# Altium schematic sheet LightBoard_v1.0.SchDoc
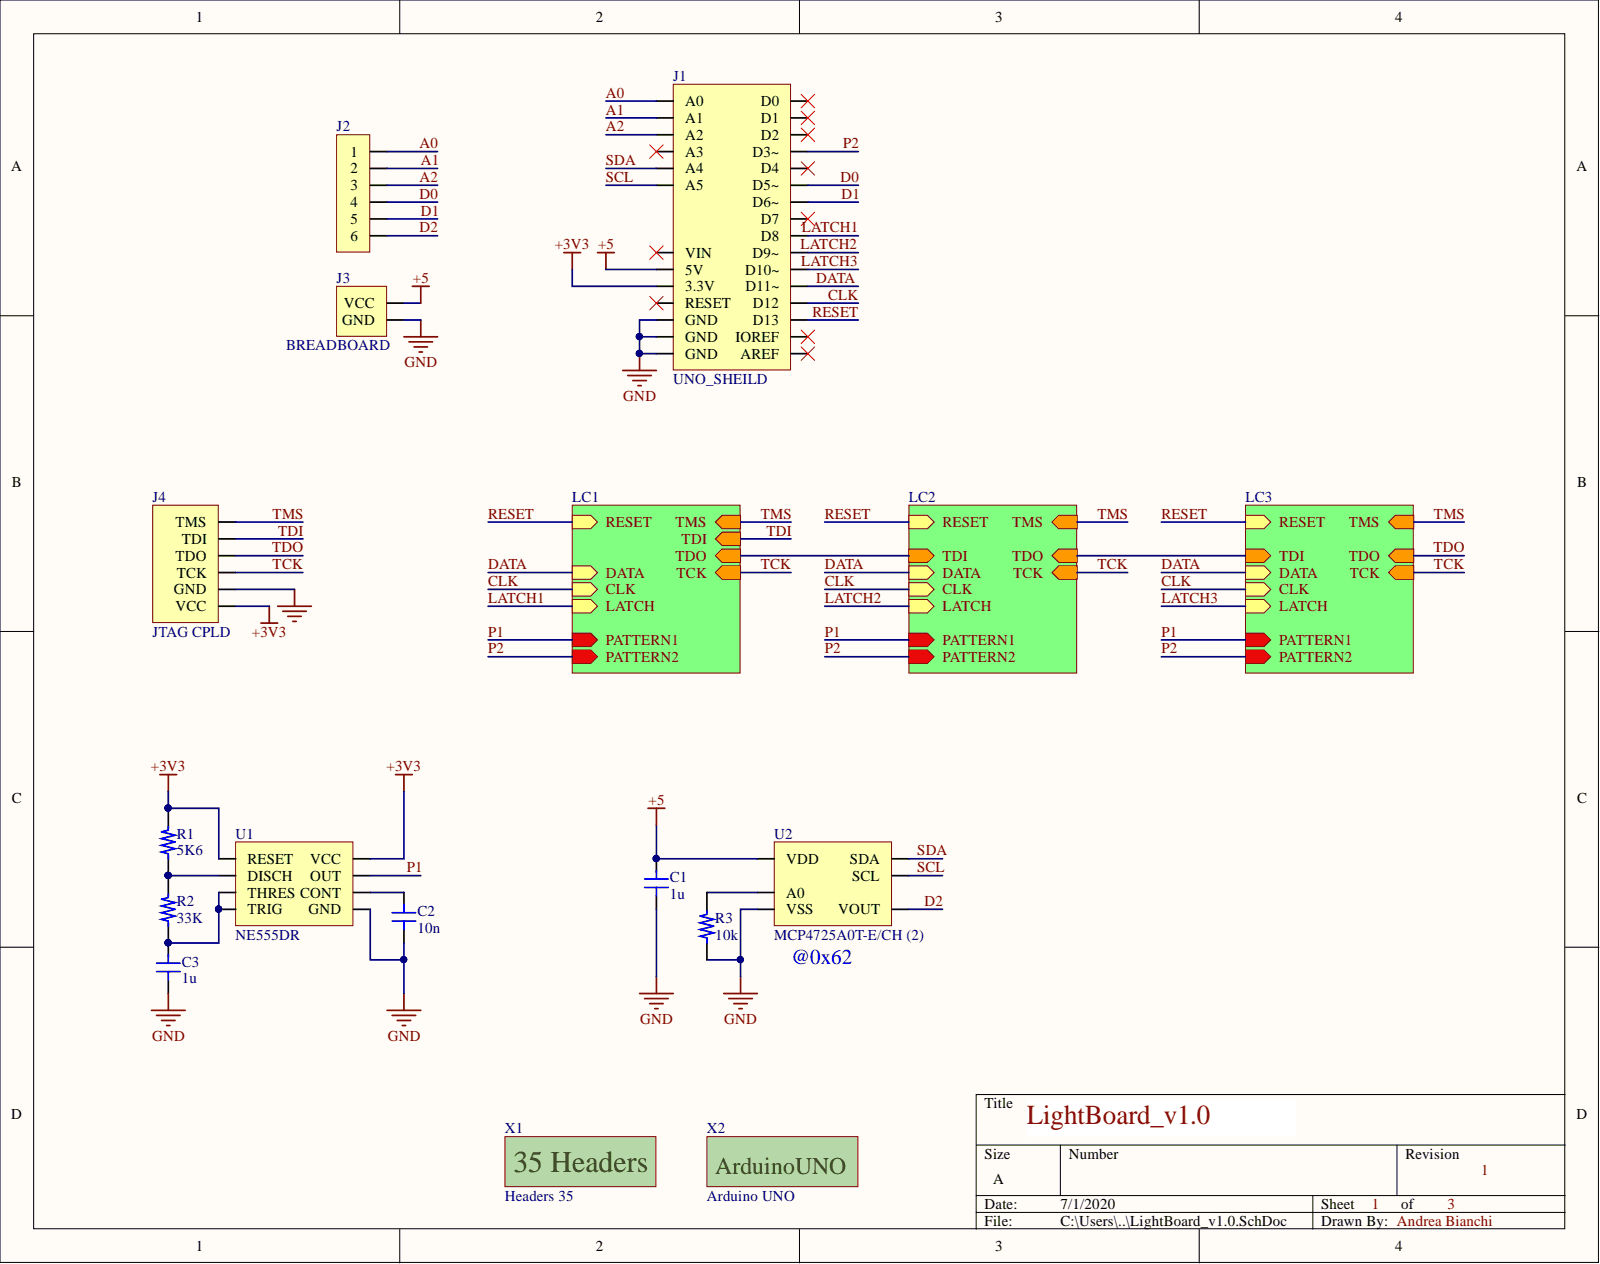
[diagram p0: LightBoard_v1.0.SchDoc]

## Parts
C1  1u
C2  10n
C3  1u
J1  UNO_SHEILD  [ARDUINO_UNO_SHIELD]
J2  HDR_6_PINS  (value not drawn)
J3  BREADBOARD  (mechanical)
J4  JTAG CPLD
R1  5K6
R2  33K
R3  10k
U1  NE555DR
U2  MCP4725A0T-E/CH (2)  Manufacturer Part Number: MCP4725A0T-E/CH  [SOT23-6]
X1  Headers 35  (mechanical)
X2  Arduino UNO  (mechanical)

## Nets
+3V3: J1.27(3.3V) J4.6(VCC) R1.2 U1.4(RESET) U1.8(VCC)
+5: C1.2 J1.26(5V) J3.1 U2.3(VDD)
GND: C1.1 C2.1 C3.1 J1.15(GND) J1.24(GND) J1.25(GND) J3.2 J4.5(GND) R3.1 U1.1(GND) U2.2(VSS)
A0: J1.22(A0) J2.1
A1: J1.21(A1) J2.2
A2: J1.20(A2) J2.3
CLK: J1.13(D12)
D0: J1.6(D5~) J2.4
D1: J1.7(D6~) J2.5
D2: J2.6 U2.1(VOUT)
DATA: J1.12(D11~)
LATCH1: J1.9(D8)
LATCH2: J1.10(D9~)
LATCH3: J1.11(D10~)
P1: U1.3(OUT)
P2: J1.4(D3~)
RESET: J1.14(D13)
SCL: J1.17(A5) U2.5(SCL)
SDA: J1.18(A4) U2.4(SDA)
TCK: J4.4(TCK)
TDI: J4.2(TDI)
TDO: J4.3(TDO)
TMS: J4.1(TMS)
NetC2_2: C2.2 U1.5(CONT)
NetC3_2: C3.2 R2.1 U1.2(TRIG) U1.6(THRES)
NetJ1_1: J1.1(D0)
NetJ1_2: J1.2(D1)
NetJ1_3: J1.3(D2)
NetJ1_5: J1.5(D4)
NetJ1_8: J1.8(D7)
NetJ1_16: J1.16(AREF)
NetJ1_19: J1.19(A3)
NetJ1_23: J1.23(VIN)
NetJ1_28: J1.28(RESET)
NetJ1_29: J1.29(IOREF)
NetR1_1: R1.1 R2.2 U1.7(DISCH)
NetR3_2: R3.2 U2.6(A0)

## Library data of the parts
C1 C3 = C0805_1u: CERAMIC CAPACITOR, 1UF, 25V, Y5V, +80, -20%, 0805, FULL REEL; Product Range:CC Series; Capacitance:1 F; Capacitance Tolerance:+80%, -20%; Dielectric Characteristic:Y5V; Voltage Rating:25V; Ceramic Capacitor Case:0805 [2012 Metric] ;RoHS Compliant: Yes; Manufacturer: Yageo; Manufacturer Part Number: CC0805ZRY5V8BB105; Tolerance: 80%; Capacitance: 1uF; Case/Package: 0805; Case Code (Imperial): 0805; Case Code (Metric): 2012; Component Kind: Standard; ComponentLink1Description: https://datasheet.ciiva.com/26863/getdatasheetpartid-419810-26863011.pdf?src-supplier=Verical; ComponentLink1URL: https://datasheet.ciiva.com/26863/getdatasheetpartid-419810-26863011.pdf?src-supplier=Verical; ComponentLink2Description: https://datasheet.ciiva.com/144/mlccorderingcode-144703.pdf?src-supplier=Digi-Key; ComponentLink2URL: https://datasheet.ciiva.com/144/mlccorderingcode-144703.pdf?src-supplier=Digi-Key; ComponentLink3Description: https://datasheet.ciiva.com/144/mlccorderingcode-144704.pdf?src-supplier=Digi-Key; ComponentLink3URL: https://datasheet.ciiva.com/144/mlccorderingcode-144704.pdf?src-supplier=Digi-Key; ComponentLink4Description: https://datasheet.ciiva.com/144/mlccorderingcode-144705.pdf?src-supplier=Digi-Key; ComponentLink4URL: https://datasheet.ciiva.com/144/mlccorderingcode-144705.pdf?src-supplier=Digi-Key; ComponentLink5Description: https://datasheet.ciiva.com/13208/upy-gp-np0-16v-to-50v-8-13208251.pdf?src-supplier=Mouser; ComponentLink5URL: https://datasheet.ciiva.com/13208/upy-gp-np0-16v-to-50v-8-13208251.pdf?src-supplier=Mouser; ComponentLink6Description: https://datasheet.ciiva.com/28450/1132289-28450860.pdf?src-supplier=Element14; ComponentLink6URL: https://datasheet.ciiva.com/28450/1132289-28450860.pdf?src-supplier=Element14; Composition: Ceramic; Depth: 1.25mm; Dielectric: Y5V; Halogen Free: Halogen Free; Height: 0.85mm; Lead Free: Lead Free; Length: 2mm; Library Name: LightBoard_v1.0.SchLib; Max Operating Temperature: 85°C; Min Operating Temperature: -30°C; Mount: Surface Mount; Package Quantity: 1; Packaging: Tape and Reel; Pricing 1: 1 = 0.16000, 10 = 0.11400, 100 = 0.05340, 500 = 0.03748, 1000 = 0.03102 (USD); Radiation Hardening: No; REACH SVHC: No SVHC; RoHS: Yes; Stock 1: 145970; Supplier 1: Digi-Key; Supplier Part Number 1: 311-1358-1-ND; Termination: SMD/SMT; Thickness: 0.033inch; Voltage Rating: 25V; Voltage Rating (DC): 25V; Weight: 0.000194oz; Width: 0.049inch
C2 = C0402_10n: Cap Ceramic 0.01uF 10V X7R 10% SMD 0402 125C Paper T/R; Manufacturer: Yageo; Manufacturer Part Number: CC0402KRX7R6BB103; Capacitance: 10nF; Case/Package: 0402; Case Code (Imperial): 0402; Case Code (Metric): 1005; Component Kind: Standard; ComponentLink1Description: https://datasheet.ciiva.com/26862/getdatasheetpartid-748492-26862629.pdf?src-supplier=Verical; ComponentLink1URL: https://datasheet.ciiva.com/26862/getdatasheetpartid-748492-26862629.pdf?src-supplier=Verical; Composition: Ceramic; Depth: 0.5mm; Dielectric: X7R; Halogen Free: Halogen Free; Lead Free: Lead Free; Length: 1mm; Library Name: LightBoard_v1.0.SchLib; Max Operating Temperature: 125°C; Min Operating Temperature: -55°C; Mount: Surface Mount; Packaging: Tape and Reel; Pricing 1: 1 = 0.10000, 10 = 0.02100, 100 = 0.00950, 500 = 0.00678, 1000 = 0.00532, 2500 = 0.00484, 5000 = 0.00445 (USD); Radiation Hardening: No; RoHS: Yes; Stock 1: 30463; Supplier 1: Digi-Key; Supplier Part Number 1: 311-1703-1-ND; Termination: SMD/SMT; Thickness: 0.022inch; Tolerance: 10%; Voltage Rating: 10V; Voltage Rating (DC): 10V; Weight: 2.3E-05oz; Width: 0.02inch
J1 = ARDUINO_UNO_SHIELD: Arduino UNO shield; Manufacturer Part Number: A000073; Core Architecture: AVR; Evaluation Kit: Yes; Number of Bits: 8; Component Kind: Standard; ComponentLink1Description: https://datasheet.ciiva.com/22632/1682193-22632354.pdf?src-supplier=Element14; ComponentLink1URL: https://datasheet.ciiva.com/22632/1682193-22632354.pdf?src-supplier=Element14; ComponentLink2Description: https://datasheet.ciiva.com/4710/unosmd-188790-4710628.pdf?src-supplier=Mouser; ComponentLink2URL: https://datasheet.ciiva.com/4710/unosmd-188790-4710628.pdf?src-supplier=Mouser; ComponentLink3Description: https://datasheet.ciiva.com/25630/0900766b811af13a-25630647.pdf?src-supplier=RSComponents; ComponentLink3URL: https://datasheet.ciiva.com/25630/0900766b811af13a-25630647.pdf?src-supplier=RSComponents; ComponentLink4Description: https://datasheet.ciiva.com/19466/2163840-19466249.pdf?src-supplier=Jameco; ComponentLink4URL: https://datasheet.ciiva.com/19466/2163840-19466249.pdf?src-supplier=Jameco; ComponentLink5Description: https://datasheet.ciiva.com/6204/a000073-web-6204795.pdf?src-supplier=Digi-Key; ComponentLink5URL: https://datasheet.ciiva.com/6204/a000073-web-6204795.pdf?src-supplier=Digi-Key; ComponentLink6Description: https://datasheet.ciiva.com/20168/73-4443-20168541.pdf?src-supplier=Rapid+Electronics; ComponentLink6URL: https://datasheet.ciiva.com/20168/73-4443-20168541.pdf?src-supplier=Rapid+Electronics; Height: 0.05inch; Length: 3inch; Library Name: LightBoard_v1.0.SchLib; Manufacturer: Arduino; Mount: Surface Mount; Package Quantity: 1; Packaging: Bulk; Pricing 1: 1 = 20.90000 (USD); REACH SVHC: Unknown; RoHS: Yes; Stock 1: 2726; Supplier 1: Digi-Key; Supplier Part Number 1: 1050-1041-ND; Weight: 0.02lb; Width: 2.5inch
J2 = H_ARDUPINS: Component Kind: Standard; Library Name: LightBoard_v1.0.SchLib
J3 = BREADBOARD: 26*2 ROWS; Library Name: LightBoard_v1.0.SchLib
J4 = H_JTAG: Component Kind: Standard; Library Name: LightBoard_v1.0.SchLib
R1 = R0402_5K6: RES SMD 5.6K OHM 5% 1/16W 0402; Manufacturer: Yageo; Manufacturer Part Number: RC0402JR-075K6L; Max Power Dissipation: 63mW; Resistance: 5.6kR; Temperature Coefficient: 100ppm/°C; Voltage Rating: 50V; Case/Package: 0402; Case Code (Imperial): 0402; Case Code (Metric): 1005; Component Kind: Standard; ComponentLink1Description: https://datasheet.ciiva.com/16746/pyu-rc0402-51-rohs-l-6-r-16746885.pdf?src-supplier=Future+Electronics; ComponentLink1URL: https://datasheet.ciiva.com/16746/pyu-rc0402-51-rohs-l-6-r-16746885.pdf?src-supplier=Future+Electronics; ComponentLink2Description: https://datasheet.ciiva.com/12577/pyu-rc0402-51-rohs-l-6-r-12577430.pdf?src-supplier=Mouser; ComponentLink2URL: https://datasheet.ciiva.com/12577/pyu-rc0402-51-rohs-l-6-r-12577430.pdf?src-supplier=Mouser; ComponentLink3Description: https://datasheet.ciiva.com/7850/1018594-7850232.pdf?src-supplier=Element14; ComponentLink3URL: https://datasheet.ciiva.com/7850/1018594-7850232.pdf?src-supplier=Element14; ComponentLink4Description: https://datasheet.ciiva.com/8892/pyu-rc0402-51-rohs-l-6-8892584.pdf?src-supplier=Avnet; ComponentLink4URL: https://datasheet.ciiva.com/8892/pyu-rc0402-51-rohs-l-6-8892584.pdf?src-supplier=Avnet; ComponentLink5Description: https://datasheet.ciiva.com/16862/pyu-rc0402-51-rohs-l-6-16862504.pdf?src-supplier=Avnet+Europe; ComponentLink5URL: https://datasheet.ciiva.com/16862/pyu-rc0402-51-rohs-l-6-16862504.pdf?src-supplier=Avnet+Europe; ComponentLink6Description: https://datasheet.ciiva.com/7850/1018594-7850233.pdf?src-supplier=Element14; ComponentLink6URL: https://datasheet.ciiva.com/7850/1018594-7850233.pdf?src-supplier=Element14; ComponentLink7Description: https://datasheet.ciiva.com/2518/pyu-r-int-thick-7-2518877.pdf?src-supplier=Digi-Key; ComponentLink7URL: https://datasheet.ciiva.com/2518/pyu-r-int-thick-7-2518877.pdf?src-supplier=Digi-Key; ComponentLink8Description: https://datasheet.ciiva.com/7983/1018594-7983597.pdf?src-supplier=Element14; ComponentLink8URL: https://datasheet.ciiva.com/7983/1018594-7983597.pdf?src-supplier=Element14; ComponentLink9Description: https://datasheet.ciiva.com/2518/pyu-r-int-thick-7-2518878.pdf?src-supplier=Digi-Key; ComponentLink9URL: https://datasheet.ciiva.com/2518/pyu-r-int-thick-7-2518878.pdf?src-supplier=Digi-Key; ComponentLink10Description: https://datasheet.ciiva.com/2518/pyu-r-int-thick-7-2518879.pdf?src-supplier=Digi-Key; ComponentLink10URL: https://datasheet.ciiva.com/2518/pyu-r-int-thick-7-2518879.pdf?src-supplier=Digi-Key; Composition: Thick Film; Contact Plating: Tin,Matte; Depth: 0.5mm; Features: Moisture Resistant; Height: 0.016inch; Lead Free: Lead Free; Length: 1mm; Library Name: LightBoard_v1.0.SchLib; Max Operating Temperature: 155°C; Military Standard: Not; Min Operating Temperature: -55°C; Number of Terminations: 2; Package Quantity: 1; Packaging: Tape and Reel; Power Rating: 63mW; Pricing 1: 1 = 0.10000, 10 = 0.01300, 100 = 0.00530, 1000 = 0.00236, 2500 = 0.00205, 5000 = 0.00169 (USD); REACH SVHC: Unknown; RoHS: Yes; Stock 1: 70470; Supplier 1: Digi-Key; Supplier Part Number 1: 311-5.6KJRCT-ND; Termination: SMD/SMT; Tolerance: 5%; Weight: 2.3E-05oz; Width: 0.5mm
R2 = R0402_33K: YAGEO - RC0402JR-0733KL - SMD Chip Resistor, 0402 [1005 Metric], 33 kohm, RC Series, 50 V, Thick Film, 63 mW - SMD Chip Resistor, 0402 [1005 Metric], 33 kohm, RC Series, 50 V, Thick Film, 63 mW; Power Rating: 63mW; Resistance: 33kR; Temperature Coefficient: 100ppm/°C; Tolerance: 5%; Voltage Rating: 50V; Case/Package: 0402; Case Code (Imperial): 0402; Case Code (Metric): 1005; Component Kind: Standard; ComponentLink1Description: https://datasheet.ciiva.com/7850/1018594-7850199.pdf?src-supplier=Element14; ComponentLink1URL: https://datasheet.ciiva.com/7850/1018594-7850199.pdf?src-supplier=Element14; ComponentLink2Description: https://datasheet.ciiva.com/16740/pyu-rc0402-51-rohs-l-6-r-16740743.pdf?src-supplier=Future+Electronics; ComponentLink2URL: https://datasheet.ciiva.com/16740/pyu-rc0402-51-rohs-l-6-r-16740743.pdf?src-supplier=Future+Electronics; ComponentLink3Description: https://datasheet.ciiva.com/5558/pyu-rc0402-51-rohs-l-6-r-5558154.pdf?src-supplier=Mouser; ComponentLink3URL: https://datasheet.ciiva.com/5558/pyu-rc0402-51-rohs-l-6-r-5558154.pdf?src-supplier=Mouser; ComponentLink4Description: https://datasheet.ciiva.com/7850/1018594-7850198.pdf?src-supplier=Element14; ComponentLink4URL: https://datasheet.ciiva.com/7850/1018594-7850198.pdf?src-supplier=Element14; ComponentLink5Description: https://datasheet.ciiva.com/7983/1018594-7983578.pdf?src-supplier=Element14; ComponentLink5URL: https://datasheet.ciiva.com/7983/1018594-7983578.pdf?src-supplier=Element14; Composition: Thick Film; Depth: 0.5mm; Lead Free: Lead Free; Length: 1mm; Library Name: LightBoard_v1.0.SchLib; Manufacturer: Yageo; Manufacturer Part Number: RC0402JR-0733KL; Max Operating Temperature: 155°C; Military Standard: Not; Min Operating Temperature: -55°C; Number of Terminations: 2; Packaging: Tape and Reel; Pricing 1: 1 = 0.10000, 10 = 0.01300, 100 = 0.00530, 1000 = 0.00236, 2500 = 0.00205, 5000 = 0.00169 (USD); REACH SVHC: No SVHC; RoHS: Yes; Stock 1: 766062; Supplier 1: Digi-Key; Supplier Part Number 1: 311-33KJRCT-ND; Termination: SMD/SMT; Working Voltage: 50V
R3 = R0402_10K: RES SMD 10K OHM 1% 1/16W 0402; Manufacturer: Yageo; Manufacturer Part Number: RC0402FR-0710KP; Max Power Dissipation: 63mW; Resistance: 10kR; Temperature Coefficient: 150ppm/°C; Case/Package: 0402; Case Code (Imperial): 0402; Case Code (Metric): 1005; Component Kind: Standard; ComponentLink1Description: http://www.yageo.com/NewPortal/yageodocoutput?fileName=/pdf/R-Chip/PYu-RC_51_RoHS_P_0.pdf&src-supplier=Digi-Key; ComponentLink1URL: http://www.yageo.com/NewPortal/yageodocoutput?fileName=/pdf/R-Chip/PYu-RC_51_RoHS_P_0.pdf&src-supplier=Digi-Key; Composition: Thick Film; Features: Moisture Resistant; Height: 0.016inch; Length: 0.039inch; Library Name: LightBoard_v1.0.SchLib; Max Operating Temperature: 155°C; Min Operating Temperature: -55°C; Number of Terminations: 2; Packaging: Tape and Reel; Pricing 1: 1 = 0.10000, 10 = 0.03500, 100 = 0.01400, 1000 = 0.00631, 2500 = 0.00548, 5000 = 0.00452 (USD); RoHS: Yes; Stock 1: 229371; Supplier 1: Digi-Key; Supplier Part Number 1: YAG1249CT-ND; Tolerance: 1%; Width: 0.02inch
U1 = 555: TEXAS INSTRUMENTS         NE555DR.             TIMER, SINGLE, 500KHZ, 16V, SOIC-8; Frequency: 100kHz; High Level Output Current: -200mA; Low Level Output Current: 200mA; Min Supply Voltage: 4.5V; Number of Timers/Counters: 1; Operating Supply Current: 10mA; Quiescent Current: 15mA; Case/Package: SOIC; Component Kind: Standard; ComponentLink1Description: https://datasheet.ciiva.com/1233/ne555-1233131.pdf?src-supplier=Digi-Key; ComponentLink1URL: https://datasheet.ciiva.com/1233/ne555-1233131.pdf?src-supplier=Digi-Key; ComponentLink2Description: https://datasheet.ciiva.com/1233/ne555-1233133.pdf?src-supplier=Digi-Key; ComponentLink2URL: https://datasheet.ciiva.com/1233/ne555-1233133.pdf?src-supplier=Digi-Key; ComponentLink3Description: https://datasheet.ciiva.com/26251/se555m-26251288.pdf?src-supplier=Avnet+Europe; ComponentLink3URL: https://datasheet.ciiva.com/26251/se555m-26251288.pdf?src-supplier=Avnet+Europe; Contact Plating: Gold; Height: 1.58mm; Lead Free: Lead Free; Length: 4.9mm; Library Name: LightBoard_v1.0.SchLib; Manufacturer: Texas Instruments; Manufacturer Part Number: NE555DR; Max Operating Temperature: 70°C; Max Supply Voltage: 16V; Min Operating Temperature: 0°C; Mount: Surface Mount; Number of Pins: 8; Package Quantity: 2500; Packaging: Tape and Reel; Pricing 1: 1 = 0.36000, 10 = 0.27500, 25 = 0.24760, 100 = 0.17100, 250 = 0.14400, 500 = 0.11700, 1000 = 0.09000 (USD); Radiation Hardening: No; REACH SVHC: No SVHC; RoHS: Yes; Stock 1: 46886; Supplier 1: Digi-Key; Supplier Part Number 1: 296-6501-1-ND; Weight: 0.002561oz; Width: 3.91mm
U2 = MCP4725A0T: DAC 1-CH Resistor-String 12-bit 6-Pin SOT-23A T/R; Manufacturer: Microchip; Input Offset Voltage (Vos): 1.1mV; Integral Nonlinearity (INL): 14.5LSB; Interface: I2C,Serial,2-Wire; Max Supply Voltage: 5.5V; Min Supply Voltage: 2.7V; Nominal Supply Current: 210uA; Number of Bits: 12; Number of Channels: 1; Output Type: Voltage; Polarity: Unipolar; Resolution: 12b; Settling Time: 6us; Supply Type: Single; Case/Package: SOT-23-6; Component Kind: Standard; ComponentLink1Description: https://datasheet.ciiva.com/12285/22039c-78124-12285942.pdf?src-supplier=Mouser; ComponentLink1URL: https://datasheet.ciiva.com/12285/22039c-78124-12285942.pdf?src-supplier=Mouser; ComponentLink2Description: https://datasheet.ciiva.com/26947/getdatasheetpartid-780909-26947786.pdf?src-supplier=Verical; ComponentLink2URL: https://datasheet.ciiva.com/26947/getdatasheetpartid-780909-26947786.pdf?src-supplier=Verical; ComponentLink3Description: https://datasheet.ciiva.com/14180/mcp4725-eng-tds-14180126.pdf?src-supplier=Distrelec; ComponentLink3URL: https://datasheet.ciiva.com/14180/mcp4725-eng-tds-14180126.pdf?src-supplier=Distrelec; ComponentLink4Description: https://datasheet.ciiva.com/7660/1470577-7660741.pdf?src-supplier=Element14; ComponentLink4URL: https://datasheet.ciiva.com/7660/1470577-7660741.pdf?src-supplier=Element14; ComponentLink5Description: https://datasheet.ciiva.com/16355/filehandler-aspxddocname-en532676-16355109.pdf?src-supplier=Future+Electronics; ComponentLink5URL: https://datasheet.ciiva.com/16355/filehandler-aspxddocname-en532676-16355109.pdf?src-supplier=Future+Electronics; ComponentLink6Description: https://datasheet.ciiva.com/8169/22039c-8169286.pdf?src-supplier=Avnet; ComponentLink6URL: https://datasheet.ciiva.com/8169/22039c-8169286.pdf?src-supplier=Avnet; ComponentLink7Description: https://datasheet.ciiva.com/16625/22039c-16625638.pdf?src-supplier=Avnet+Europe; ComponentLink7URL: https://datasheet.ciiva.com/16625/22039c-16625638.pdf?src-supplier=Avnet+Europe; ComponentLink8Description: https://datasheet.ciiva.com/1359/22039d-1359787.pdf?src-supplier=Digi-Key; ComponentLink8URL: https://datasheet.ciiva.com/1359/22039d-1359787.pdf?src-supplier=Digi-Key; ComponentLink9Description: https://datasheet.ciiva.com/1359/22039d-1359788.pdf?src-supplier=Digi-Key; ComponentLink9URL: https://datasheet.ciiva.com/1359/22039d-1359788.pdf?src-supplier=Digi-Key; ComponentLink10Description: https://datasheet.ciiva.com/1359/22039d-1359789.pdf?src-supplier=Digi-Key; ComponentLink10URL: https://datasheet.ciiva.com/1359/22039d-1359789.pdf?src-supplier=Digi-Key; Contact Plating: Tin,Matte; Height: 1.3mm; Lead Free: Lead Free; Length: 3.1mm; Max Operating Temperature: 125°C; Min Operating Temperature: -40°C; Mount: Surface Mount; Number of Converters: 1; Number of D/A Converters: 1; Number of DAC Channels: 1; Number of Outputs: 1; Number of Pins: 6; Package Quantity: 3000; Packaging: Tape and Reel; Pricing 1: 1 = 0.96000, 25 = 0.80000, 100 = 0.73000 (USD); Radiation Hardening: No; REACH SVHC: No SVHC; RoHS: Yes; Stock 1: 1576; Supplier 1: Digi-Key; Supplier Part Number 1: MCP4725A0T-E/CHCT-ND; Termination: SMD/SMT; Width: 1.8mm; Library Name: VirtualSensors.SchLib
X1 = M_Headers35: Conn Header Vert 35POS 2.54MM; Manufacturer: Preci-Dip; Manufacturer Part Number: 890-70-035-10-001101; Connector Type: Male Pin; Number of Positions: 35; Pitch: 0.1inch; Color: Black; ComponentLink1Description: https://datasheet.ciiva.com/18660/catalog-18660493.pdf?src-supplier=Digi-Key; ComponentLink1URL: https://datasheet.ciiva.com/18660/catalog-18660493.pdf?src-supplier=Digi-Key; Contact Mating Length: 0.236inch; Contact Plating: Gold; Library Name: LightBoard_v1.0.SchLib; Mount: Through Hole; Number of Rows: 1; Package Quantity: 590; Packaging: Bulk; Pricing 1: 590 = 0.81137 (USD); RoHS: Yes; Stock 1: 0; Supplier 1: Digi-Key; Supplier Part Number 1: 890-70-035-10-001101-ND; Termination: Solder
X2 = M_ARDUINO_UNO: Description: Arduino UNO; Library Name: LightBoard_v1.0.SchLib
